# Altium schematic sheet Main.SchDoc
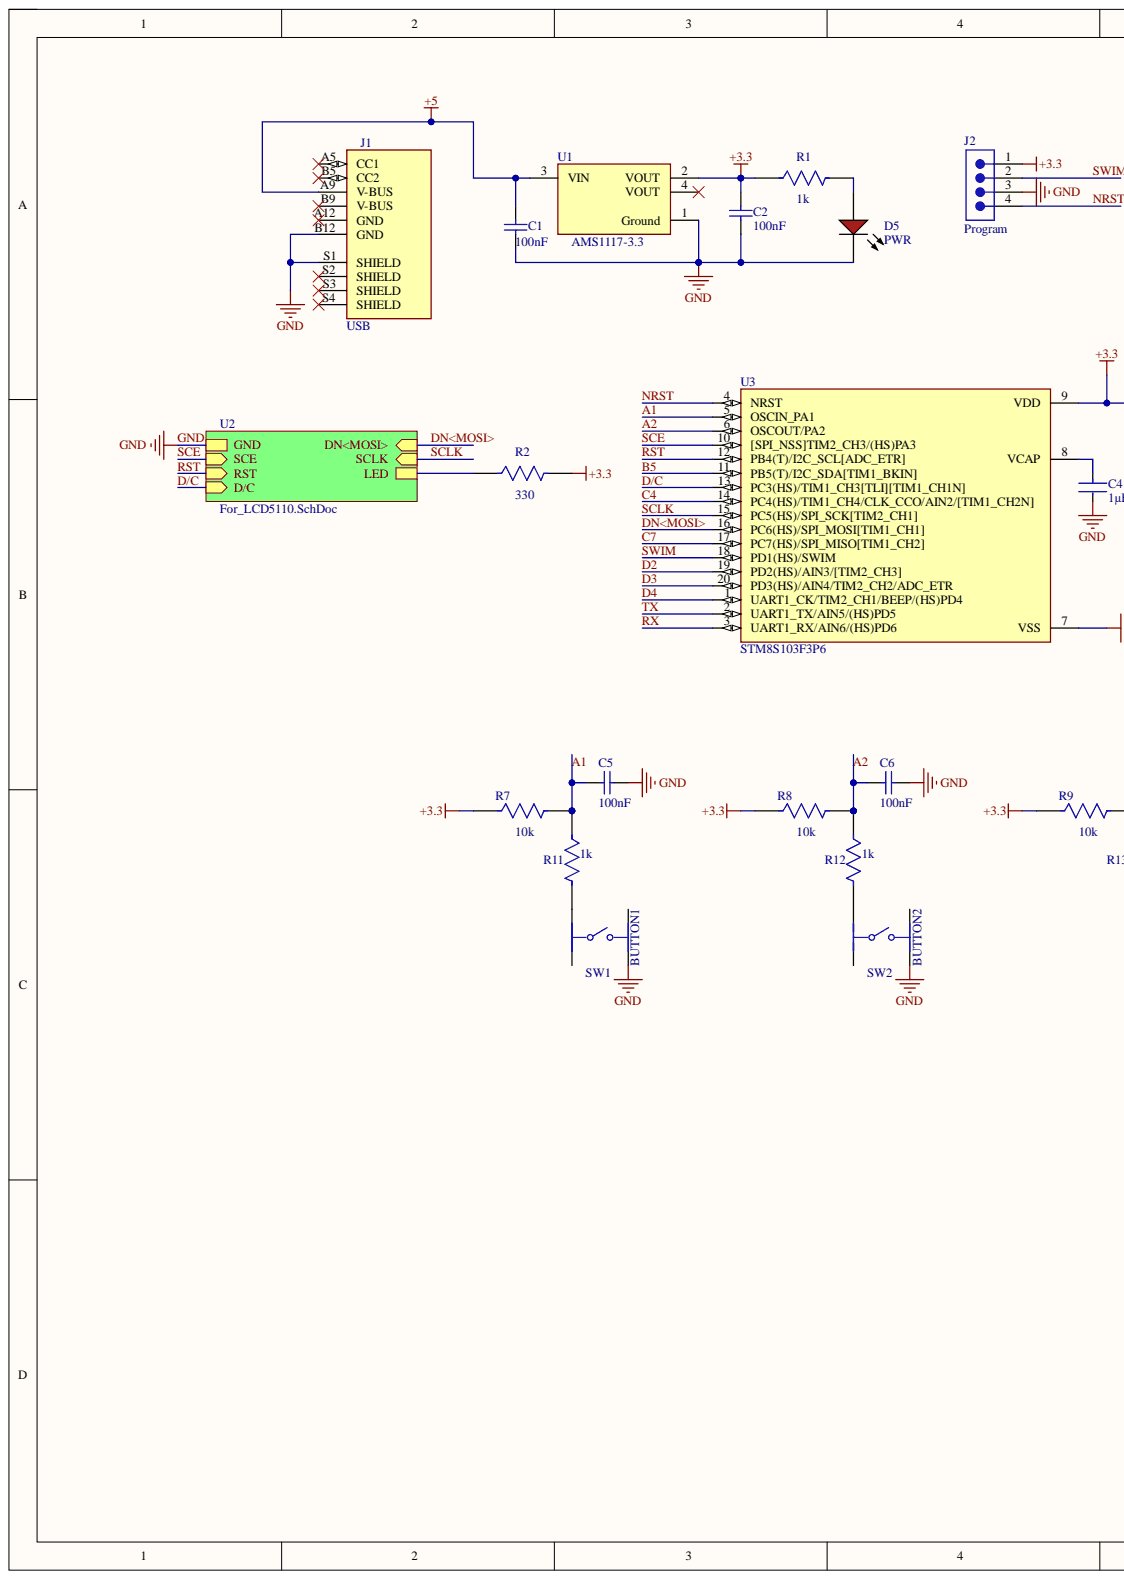
[diagram p0: Main.SchDoc, left]
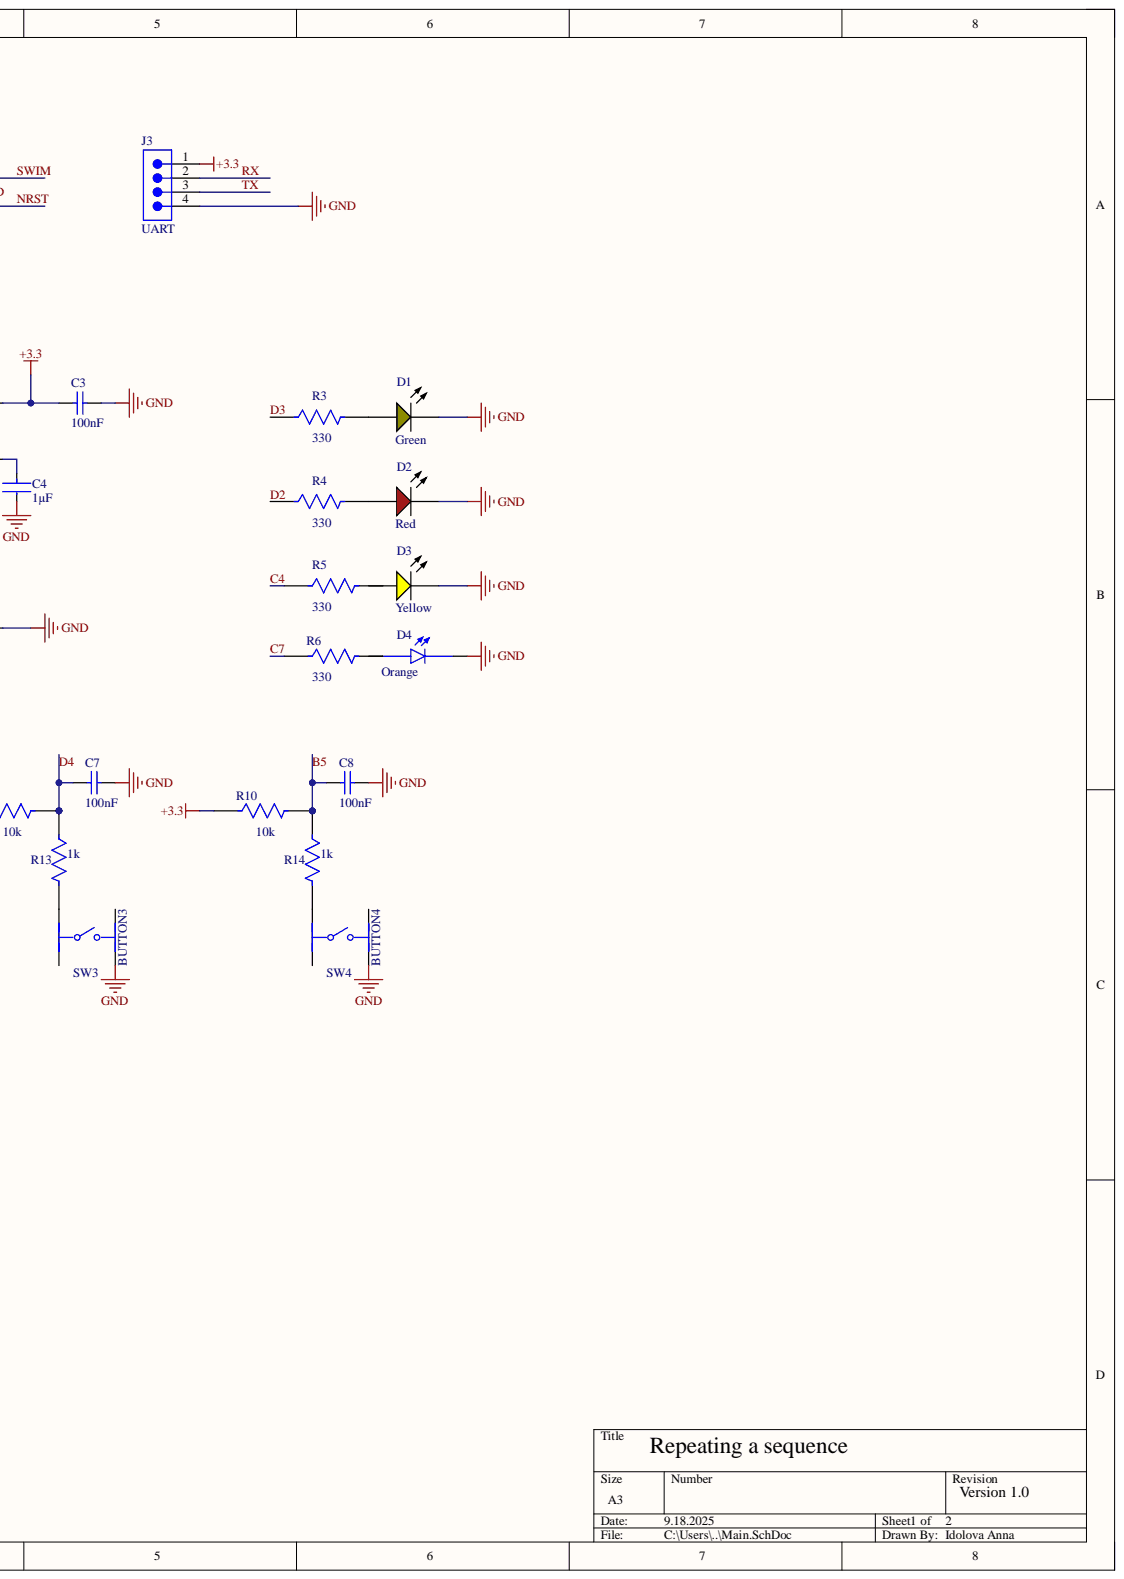
[diagram p1: Main.SchDoc, right]

## Parts
C1  value 100nF  [CAPC1206(3216)100_N]  (hidden comment: CAPACITOR_1206ZC104JAT2A)
C2  value 100nF  [CAPC1206(3216)100_N]  (hidden comment: CAPACITOR_1206ZC104JAT2A)
C3  value 100nF  [CAPC1206(3216)100_N]  (hidden comment: CAPACITOR_1206ZC104JAT2A)
C4  value 1µF  [FP-CL21-IPC_B]  (hidden comment: CL21F105ZAFNNNF)
C5  value 100nF  [CAPC1206(3216)100_N]  (hidden comment: CAPACITOR_1206ZC104JAT2A)
C6  value 100nF  [CAPC1206(3216)100_N]  (hidden comment: CAPACITOR_1206ZC104JAT2A)
C7  value 100nF  [CAPC1206(3216)100_N]  (hidden comment: CAPACITOR_1206ZC104JAT2A)
C8  value 100nF  [CAPC1206(3216)100_N]  (hidden comment: CAPACITOR_1206ZC104JAT2A)
D1  Green  [KING-WP7113SGD_V]
D2  Red  [KING-WP7113SRDD_V]
D3  Yellow  [KING-WP7113SYD_V]
D4  Orange  [LED_WP7113SEC]
D5  PWR  [KING-WP7113SRDD_V]
J1  USB  [CUI_UJC-HP-3-SMT-TR]
J2  Program  [61300411021]
J3  UART  [61300411021]
R1  value 1k  [FP-RC1005-IPC_C]  (hidden comment: RESISTOR__RC1005F102CS)
R2  value 330  [FP-MCR18-IPC_B]  (hidden comment: MCR18EZPF3300)
R3  value 330  [FP-MCR18-IPC_B]  (hidden comment: MCR18EZPF3300)
R4  value 330  [FP-MCR18-IPC_B]  (hidden comment: MCR18EZPF3300)
R5  value 330  [FP-MCR18-IPC_B]  (hidden comment: MCR18EZPF3300)
R6  value 330  [FP-MCR18-IPC_B]  (hidden comment: MCR18EZPF3300)
R7  value 10k  [FP-TFCR0402-IPC_A]  (hidden comment: TFCR0402-16W-C-1002BT)
R8  value 10k  [FP-TFCR0402-IPC_A]  (hidden comment: TFCR0402-16W-C-1002BT)
R9  value 10k  [FP-TFCR0402-IPC_A]  (hidden comment: TFCR0402-16W-C-1002BT)
R10  value 10k  [FP-TFCR0402-IPC_A]  (hidden comment: TFCR0402-16W-C-1002BT)
R11  value 1k  [FP-RC1005-IPC_C]  (hidden comment: RESISTOR__RC1005F102CS)
R12  value 1k  [FP-RC1005-IPC_C]  (hidden comment: RESISTOR__RC1005F102CS)
R13  value 1k  [FP-RC1005-IPC_C]  (hidden comment: RESISTOR__RC1005F102CS)
R14  value 1k  [FP-RC1005-IPC_C]  (hidden comment: RESISTOR__RC1005F102CS)
SW1  BUTTON1  [FP-PTS645SM43SMTR92LFS-MFG]
SW2  BUTTON2  [FP-PTS645SM43SMTR92LFS-MFG]
SW3  BUTTON3  [FP-PTS645SM43SMTR92LFS-MFG]
SW4  BUTTON4  [FP-PTS645SM43SMTR92LFS-MFG]
U1  AMS1117-3.3  [FP-AMS1117-IPC_B]
U3  STM8S103F3P6  [SOP65P640X120-20N]

## Nets
+3.3: C2.2 C3.1 J2.1 J3.1 R1.1 R2.2 R7.1 R8.1 R9.1 R10.1 U1.2(VOUT) U3.9(VDD)
+5: C1.2 J1.A9(V-BUS) U1.3(VIN)
A1: C5.1 R7.2 R11.1 U3.5(OSCIN_PA1)
A2: C6.1 R8.2 R12.1 U3.6(OSCOUT/PA2)
B5: C8.1 R10.2 R14.1 U3.11(PB5(T)/I2C_SDA[TIM1_BKIN])
C4: R5.1 U3.14(PC4(HS)/TIM1_CH4/CLK_CCO/AIN2/[TIM1_CH2N])
C7: R6.1 U3.17(PC7(HS)/SPI_MISO[TIM1_CH2])
D2: R4.1 U3.19(PD2(HS)/AIN3/[TIM2_CH3])
D3: R3.1 U3.20(PD3(HS)/AIN4/TIM2_CH2/ADC_ETR)
D4: C7.1 R9.2 R13.1 U3.1(UART1_CK/TIM2_CH1/BEEP/(HS)PD4)
D/C: U3.13(PC3(HS)/TIM1_CH3[TLI][TIM1_CH1N])
DN<MOSI>: U3.16(PC6(HS)/SPI_MOSI[TIM1_CH1])
GND: C1.1 C2.1 C3.2 C4.2 C5.2 C6.2 C7.2 C8.2 D1.2 D2.2 D3.2 D4.C D5.2 J1.B12(GND) J1.S1(SHIELD) J2.3 J3.4 SW1.2 SW2.2 SW3.2 SW4.2 U1.1(Ground) U3.7(VSS)
NRST: J2.4 U3.4(NRST)
RST: U3.12(PB4(T)/I2C_SCL[ADC_ETR])
RX: J3.2 U3.3(UART1_RX/AIN6/(HS)PD6)
SCE: U3.10([SPI_NSS]TIM2_CH3/(HS)PA3)
SCLK: U3.15(PC5(HS)/SPI_SCK[TIM2_CH1])
SWIM: J2.2 U3.18(PD1(HS)/SWIM)
TX: J3.3 U3.2(UART1_TX/AIN5/(HS)PD5)
NetC4_1: C4.1 U3.8(VCAP)
NetD1_1: D1.1 R3.2
NetD2_1: D2.1 R4.2
NetD3_1: D3.1
NetD4_A: D4.A
NetD5_1: D5.1 R1.2
NetJ1_A5: J1.A5(CC1)
NetJ1_A12: J1.A12(GND)
NetJ1_B5: J1.B5(CC2)
NetJ1_B9: J1.B9(V-BUS)
NetJ1_S2: J1.S2(SHIELD)
NetJ1_S3: J1.S3(SHIELD)
NetJ1_S4: J1.S4(SHIELD)
NetR2_1: R2.1
NetR5_2: R5.2
NetR6_2: R6.2
NetR11_2: R11.2 SW1.3
NetR12_2: R12.2 SW2.3
NetR13_2: R13.2 SW3.3
NetR14_2: R14.2 SW4.3
NetSW1_1: SW1.1
NetSW1_4: SW1.4
NetSW2_1: SW2.1
NetSW2_4: SW2.4
NetSW3_1: SW3.1
NetSW3_4: SW3.4
NetSW4_1: SW4.1
NetSW4_4: SW4.4
NetU1_4: U1.4(VOUT)

## Library data of the parts
C1-C3 C5-C8 = CMP-001-00221-1: Ceramic Capacitor, Multilayer, Ceramic, 10V, 5% +Tol, 5% -Tol, X7R, 15% TC, 0.1uF, Surface Mount, 1206; Multilayer: Yes; Capacitor Type: Ceramic; Case/Package: 1206; Component Kind: Standard; Dielectric Material: Ceramic; Height: 0.94mm; JESD-609 Code: e3; Length: 3.2mm; Max Operating Temperature: 125°C; Min Operating Temperature: -55°C; Mounting Technology: Surface Mount; Package Shape: Rectangular; Package Style: SMTMeter; Packaging: Tape and Reel; Pins: 2; Rated DC Voltage (URdc): 10V; Reach SVHC Compliant: Yes; RoHS Compliant: Yes; Temperature Characteristics Code: X7R; Terminal Finish: Nickel; Termination: Wraparound; Tolerance  (fill in as +-): 5%; Tolerance (fill in as +-): 5%; Width: 1.6mm; Library Name: National Technical University of Ukraine Igor Sikorsky Kyiv Polytechnic Institute- Educator Program
C4 = CMP-001-00222-1: Ceramic Capacitor, Multilayer, Ceramic, 25V, 80% +Tol, 20% -Tol, Y5V, -82/+22% TC, 1uF, Surface Mount, 0805; Multilayer: Yes; Capacitor Type: Ceramic; Case/Package: 0805; Component Kind: Standard; Dielectric Material: Ceramic; Height: 1.35mm; JESD-609 Code: e3; Length: 2mm; Max Operating Temperature: 85°C; Min Operating Temperature: -30°C; Mounting Technology: Surface Mount; Package Shape: Rectangular; Package Style: SMTMeter; Packaging: Tape and Reel; Pins: 2; Rated DC Voltage (URdc): 25V; Reach SVHC Compliant: Yes; RoHS Compliant: Yes; Temperature Characteristics Code: Y5V; Terminal Finish: Nickel; Termination: Wraparound; Tolerance  (fill in as +-): 20%; Tolerance (fill in as +-): 80%; Width: 1.25mm; Library Name: National Technical University of Ukraine Igor Sikorsky Kyiv Polytechnic Institute- Educator Program
D1 = CMP-007-00010-2: LED Green, 62.5 mW, 25 mA, -40 to 85 degC, 2-Pin THD, RoHS, Bulk; Manufacturer: Kingbright; Forward Voltage: 2.2V; Lens Style: Dome; Luminous Intensity: 40mcd; Power: 62.5mW; Viewing Angle: 30degrees; Case/Package: Radial; Colour: Green; Component Kind: Standard; Datasheet URL: http://www.kingbrightusa.com/images/catalog/SPEC/WP7113SGD.pdf; Datasheet Version: Rev. 6B, 01/2015; Illumination Colour: Green; Mounting Technology: TH; Nominal Vf: 2.2V; Package Description: 2-Pin Through Hole Device, Dia 5.9 mm, Body Length 8.6 mm; Package Quantity: 1000; Package Reference: WP7113SGD; Packaging: Bulk; RoHS Compliant: True; Library Name: National Technical University of Ukraine Igor Sikorsky Kyiv Polytechnic Institute- Educator Program
D2 D5 = CMP-007-00033-2: The Super Bright Red Source Color Device, 1.85 V, -40 to 85 degC, 2-Pin THD, RoHS, Tape and Reel; Manufacturer: Kingbright; Forward Voltage: 1.85V; Lens Style: Diffused; Luminous Intensity: 300mcd; Viewing Angle: 30degrees; Case/Package: Radial; Colour: Red; Component Kind: Standard; Datasheet URL: http://www.kingbrightusa.com/images/catalog/SPEC/WP7113SRD-D.pdf; Datasheet Version: Rev. 6A, 07/2016; Illumination Colour: Red; Mounting Technology: TH; Nominal Vf: 1.85V; Package Description: 2-Pin Through Hole Device, Dia 5.9 mm, Body Length 8.6 mm; Package Quantity: 500; Package Reference: WP7113SRDD; Packaging: Bulk; RoHS Compliant: True; Library Name: National Technical University of Ukraine Igor Sikorsky Kyiv Polytechnic Institute- Educator Program
D3 = CMP-007-00034-2: The Super Bright Yellow Source Color Device, 2 V, -40 to 85 degC, 2-Pin THD, RoHS, Tape and Reel; Manufacturer: Kingbright; Forward Current: 20mA; Forward Voltage: 2V; Lens Style: Diffused; Luminous Intensity: 600mcd; Viewing Angle: 30degrees; Case/Package: Radial; Colour: Yellow; Component Kind: Standard; Datasheet URL: http://www.kingbrightusa.com/images/catalog/SPEC/WP7113SYD.pdf; Datasheet Version: Rev. 7B, 01/2015; Illumination Colour: Yellow; Mounting Technology: TH; Nominal Vf: 2V; Package Description: 2-Pin Through Hole Device, Dia 5.9 mm, Body Length 8.6 mm; Package Quantity: 500; Package Reference: WP7113SYD; Packaging: Bulk; RoHS Compliant: True; Library Name: National Technical University of Ukraine Igor Sikorsky Kyiv Polytechnic Institute- Educator Program
D4 = CMP-004-00038-1: LED Thru-Hole Orange T1-3/4 5mm Clear Lens 30mA 610nm 2500mcd Round; MF: Kingbright; MP: WP7113SEC; Case/Package: Radial Kingbright; Check_prices: https://www.snapeda.com/parts/WP7113SEC/Kingbright/view-part/?ref=eda; Component Kind: Standard; Component Type: Standard; Design Item ID: WP7113SEC; Pins: 2; SnapEDA_Link: https://www.snapeda.com/parts/WP7113SEC/Kingbright/view-part/?ref=snap; Source: WP7113SEC.SchLib; Library Name: National Technical University of Ukraine Igor Sikorsky Kyiv Polytechnic Institute- Educator Program
J1 = CMP-074-000000-1: MP: UJC-HP-3-SMT-TR; Availability: Unavailable; Component Kind: Standard; Component Type: Standard; Design Item ID: UJC-HP-3-SMT-TR; Package: None; Pin Count: 10; Price: None; Source: UJC-HP-3-SMT-TR.SchLib; Library Name: National Technical University of Ukraine Igor Sikorsky Kyiv Polytechnic Institute- Educator Program
J2 J3 = CMP-043-000000-1: NexarPartId: 31153320; Connector Type: Male Pin; Contact Resistance: 20m?; Current Rating: 3A; Gender: Male; Number of Contacts: 4; Operating Supply Voltage: 250V; Pitch: 2.54mm; Color: Black; Component Kind: Standard; Component Type: Standard; Contact Material: Copper; Contact Mating Length: 5.9944mm; Contact Plating: Gold; Design Item ID: CMP-1502-01063-3; Lead Pitch: 2.54mm; Mount: Through Hole; Number of Pins: 4; Number of Positions: 4; Number of Rows: 1; Pin Count: 4; REACH SVHC: Unknown; RoHS: Compliant; Source: Components - 25.08.25 - 11.48.SchLib; Termination: Solder; Library Name: National Technical University of Ukraine Igor Sikorsky Kyiv Polytechnic Institute- Educator Program
R1 R11-R14 = CMP-009-00419-1: Fixed Resistor, Metal Glaze/thick Film, 0.06W, 1000ohm, 50V, 1% +/-Tol, 100ppm/Cel, Surface Mount, 0402; Package Height: 0.35mm; Package Length: 1mm; Package Width: 0.5mm; Power: 60mW; Temperature Coefficient (ppm/Cel): 100; Case/Package: 0402; Component Kind: Standard; Construction: Chip; JESD-609 Code: e3; Max Operating Temperature: 125°C; Min Operating Temperature: -55°C; Mounting Technology: Surface Mount; Package Shape: Rectangular; Packaging: Tape and Reel; Pins: 2; Reach SVHC Compliant: Yes; Resistor Type: FIXED RESISTOR; RoHS Compliant: Yes; Technology: METAL GLAZE/THICK FILM; Terminal Finish: Nickel; Tolerance: 1%; Working Voltage: 50V; Library Name: National Technical University of Ukraine Igor Sikorsky Kyiv Polytechnic Institute- Educator Program
R2-R6 = CMP-009-00420-1: Fixed Resistor, Metal Glaze/thick Film, 0.25W, 330ohm, 200V, 1% +/-Tol, 100ppm/Cel, Surface Mount, 1206; Package Height: 0.55mm; Package Length: 3.2mm; Package Width: 1.6mm; Power: 250mW; Rated Temperature: 70°C; Reference Standard: TS 16949; Temperature Coefficient (ppm/Cel): 100; Case/Package: 1206; Component Kind: Standard; Construction: Rectangular; JESD-609 Code: e3; Max Operating Temperature: 155°C; Min Operating Temperature: -55°C; Mounting Technology: Surface Mount; Package Shape: Rectangular; Packaging: Tape and Reel; Pins: 2; Reach SVHC Compliant: Yes; Resistor Type: FIXED RESISTOR; RoHS Compliant: Yes; Technology: METAL GLAZE/THICK FILM; Terminal Finish: Nickel; Termination: Wraparound; Tolerance: 1%; Working Voltage: 200V; Library Name: National Technical University of Ukraine Igor Sikorsky Kyiv Polytechnic Institute- Educator Program
R7-R10 = CMP-009-00435-1: Fixed Resistor, Thin Film, 0.063W, 10000ohm, 25V, 0.1% +/-Tol, 50ppm/Cel, Surface Mount, 0402; Power Dissipation: 63mW; Temperature Coefficient: 50ppm/°C; Case/Package: 0402; China RoHS: Compliant; Component Kind: Standard; Composition: Thin Film; Height: 300µm; Lifecycle Status: Production; Max Operating Temperature: 155°C; Min Operating Temperature: -55°C; Mounting Technology: Surface Mount; Number of Terminals: 2; Power Rating: 63mW; REACH SVHC: Yes; RoHS: Compliant; Tolerance: 0.1%; Voltage Rating: 25V; Width: 500µm; Working Voltage: 25V; Library Name: National Technical University of Ukraine Igor Sikorsky Kyiv Polytechnic Institute- Educator Program
SW1-SW4 = CMP-00012-1: Keypad Switch, 1 Switches, SPST, Momentary-tactile, 0.05A, 12VDC, 1.57N, 4 PCB Hole Cnt, Solder Terminal, Surface Mount-straight; Actuator Type: Pushbutton; Body Breadth: 5.9944mm; Body Height: 3.4544mm; Contact Current(DC)-Max: 50mA; Contact DC Max Rating R Load: 0.05A@12VDC; Contact Resistance: 0.1mR; Contact Voltage(DC)-Max: 12V; Dielectric Withstand Volts: 250VACV; Insulation Resistance: 100GR; PCB Hole Count: 4; Body Length or Diameter: 5.9944mm; Component Kind: Standard; JESD-609 Code: e4; Max Operating Temperature: 60°C; Min Operating Temperature: -20°C; Mounting Technology: Surface Mount; Reach SVHC Compliant: Yes; RoHS Compliant: Yes; Switch Action: MOMENTARY; Switch Function: SPST; Switch Type: Keypad; Terminal Finish: Silver; Terminal Length: 0.138inch; Termination: Solder; Library Name: National Technical University of Ukraine Igor Sikorsky Kyiv Polytechnic Institute- Educator Program
U1 = CMP-012-00000-1: Fixed Positive LDO Regulator, 3.3V, 1.3V Dropout, PDSO3; Channels: 1; Output Current1-Max: 1A; Output Voltage1-Max: 3.399V; Output Voltage1-Min: 3.201V; Package Body Material: Plastic; Regulator Type: FIXED POSITIVE SINGLE OUTPUT LDO REGULATOR; Case/Package: SOP; Component Kind: Standard; Dropout Voltage1-Max: 1.3V; Height - Seated (Max): 1.8mm; JESD-30 Code: R-PDSO-G3; Length: 6.505mm; Operating Temperature, TJ-Max: 125°C; Operating Temperature, TJ-Min: -40°C; Output Voltage1-Nom: 3.3V; Package Shape: Rectangular; Package Style: SMALL OUTLINEMeter; Pins: 3; Reach SVHC Compliant: Yes; RoHS Compliant: Yes; Surface Mount: Yes; Terminal Form: Gull Wing; Terminal Pitch: 2.29mm; Terminal Position: DUAL; Width: 3.505mm; Library Name: National Technical University of Ukraine Igor Sikorsky Kyiv Polytechnic Institute- Educator Program
U3 = CMP-031-00014-1: STM8 series Microcontroller IC 8-Bit 16MHz 8KB (8K x 8) FLASH 20-TSSOP; MF: STMicroelectronics; Case/Package: TSSOP-20 STMicroelectronics; Check_prices: https://www.snapeda.com/parts/STM8S103F3P6/STMicroelectronics/view-part/?ref=eda; Component Kind: Standard; Component Type: Standard; Design Item ID: STM8S103F3P6; MP: STM8S103F3P6; Pins: 20; SnapEDA_Link: https://www.snapeda.com/parts/STM8S103F3P6/STMicroelectronics/view-part/?ref=snap; Source: STM8S103F3P6.SchLib; Library Name: National Technical University of Ukraine Igor Sikorsky Kyiv Polytechnic Institute- Educator Program
